# Altium schematic sheet CTRL.SchDoc
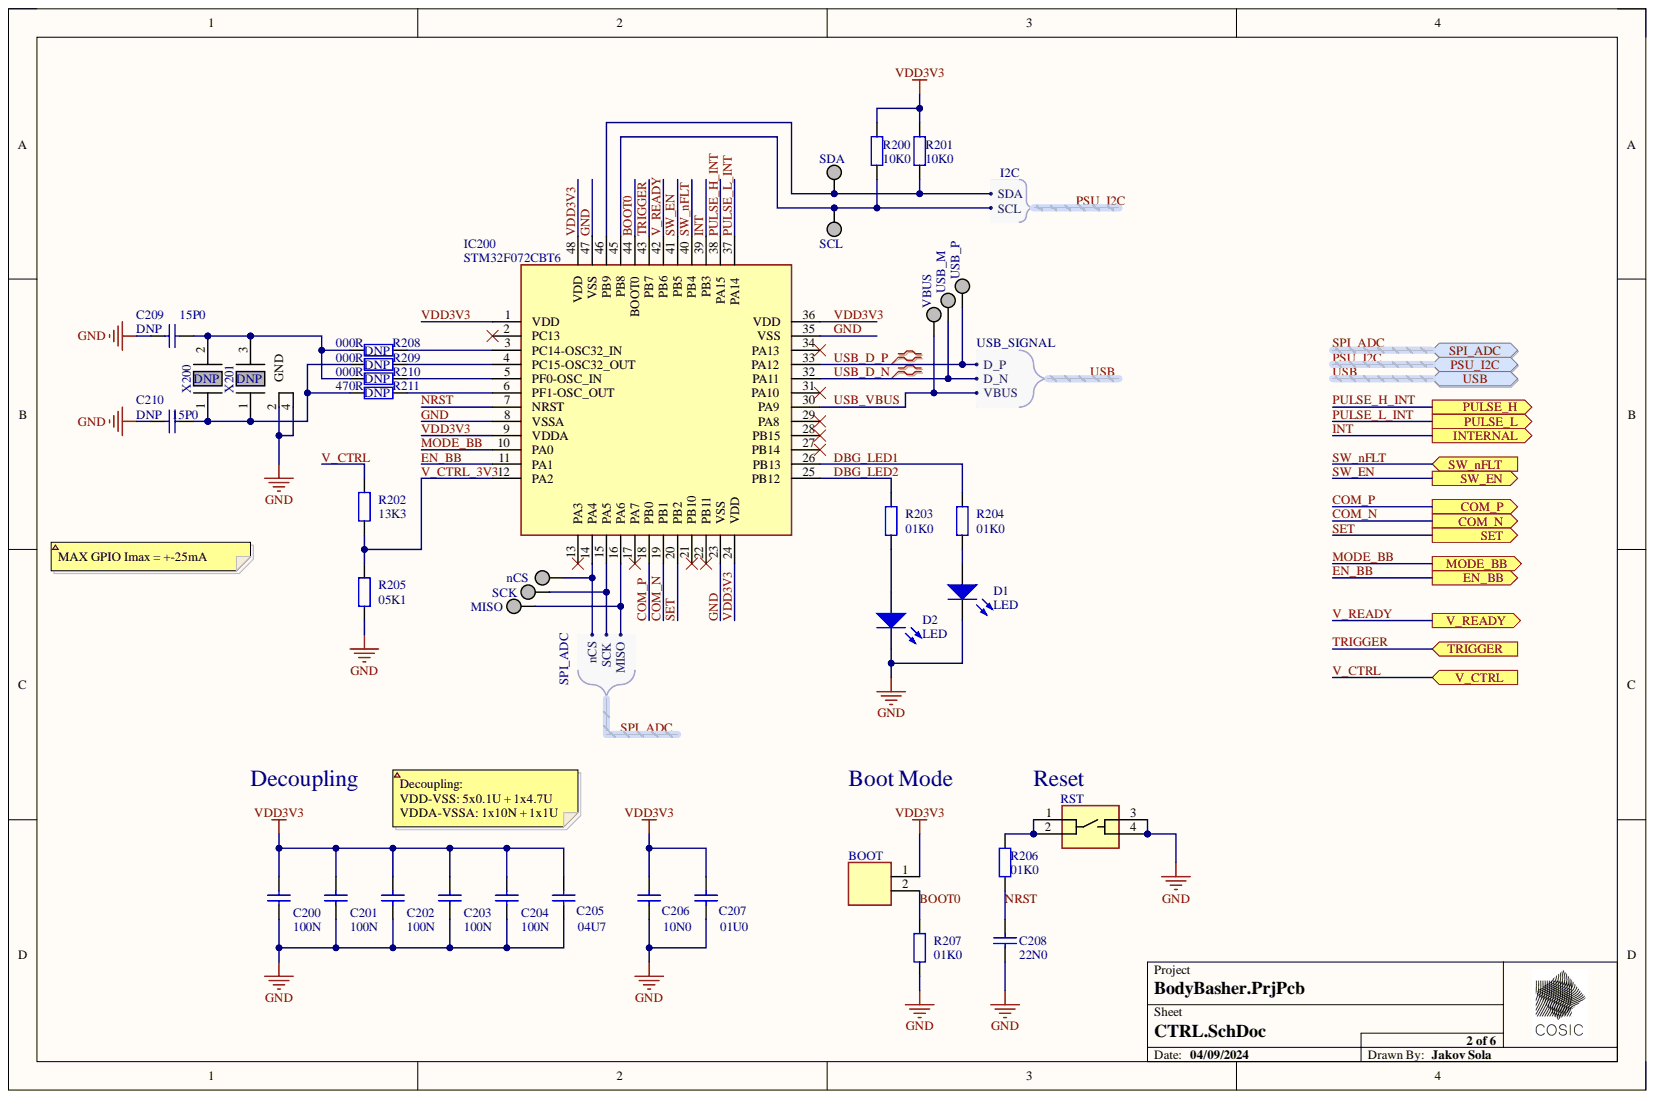
[diagram p0: CTRL.SchDoc]

## Parts
BOOT  CON_2P  [2MMHBS02VT02HTB]  (value not drawn)
C200  value 100N  [CAPC0603N]  (hidden comment: Cap)
C201  value 100N  [CAPC0603N]  (hidden comment: Cap)
C202  value 100N  [CAPC0603N]  (hidden comment: Cap)
C203  value 100N  [CAPC0603N]  (hidden comment: Cap)
C204  value 100N  [CAPC0603N]  (hidden comment: Cap)
C205  value 04U7  [CAPC1206N]  (hidden comment: Cap)
C206  VALUE_4C: 10N0  [CAPC0603N]  (hidden comment: Cap)
C207  VALUE_4C: 01U0  [CAPC0603N]  (hidden comment: Cap)
C208  VALUE_4C: 22N0  [CAPC0603N]  (hidden comment: Cap)
C209  POPULATE: DNP  VALUE_4C: 15P0  [CAPC0603N]  (hidden comment: Cap)
C210  POPULATE: DNP  VALUE_4C: 15P0  [CAPC0603N]  (hidden comment: Cap)
D1  LED  [LED0603_GREEN]
D2  LED  [LED0603_GREEN]
IC200  STM32F0  [LQFP48]
MISO  TP  [TP]  (value not drawn)
nCS  TP  [TP]  (value not drawn)
R200  VALUE_4C: 10K0  [RESC0603N]  (hidden comment: Res)
R201  VALUE_4C: 10K0  [RESC0603N]  (hidden comment: Res)
R202  value 13K3  [RESC0603N]  (hidden comment: Res)
R203  VALUE_4C: 01K0  [RESC0603N]  (hidden comment: Res)
R204  VALUE_4C: 01K0  [RESC0603N]  (hidden comment: Res)
R205  VALUE_4C: 05K1  [RESC0603N]  (hidden comment: Res)
R206  VALUE_4C: 01K0  [RESC0603N]  (hidden comment: Res)
R207  VALUE_4C: 01K0  [RESC0603N]  (hidden comment: Res)
R208  value 000R  POPULATE: DNP  [RESC0603N]  (hidden comment: Res)
R209  value 000R  POPULATE: DNP  [RESC0603N]  (hidden comment: Res)
R210  value 000R  POPULATE: DNP  [RESC0603N]  (hidden comment: Res)
R211  value 470R  POPULATE: DNP  [RESC0603N]  (hidden comment: Res)
RST  4P_BTN  [FSM2J_1]  (value not drawn)
SCK  TP  [TP]  (value not drawn)
SCL  TP  [TP]  (value not drawn)
SDA  TP  [TP]  (value not drawn)
USB_M  TP  [TP]  (value not drawn)
USB_P  TP  [TP]  (value not drawn)
VBUS  TP  [TP]  (value not drawn)
X200  POPULATE: DNP  [XTAL_ABS07-32.768KHZ-7-T]  (hidden comment: CRY_2P)
X201  POPULATE: DNP  [FC3BAEBDI80T1]  (hidden comment: CRY_4P)

## Ports
COM_N, COM_P, EN_BB, INTERNAL, MODE_BB, PSU_I2C, PSU_I2C.SCL, PSU_I2C.SDA, PULSE_H, PULSE_L, SET, SPI_ADC, SPI_ADC.MISO, SPI_ADC.nCS, SPI_ADC.SCK, SW_EN, SW_nFLT, TRIGGER, USB, USB.D_N, USB.D_P, USB.VBUS, V_CTRL, V_READY

## Nets
BOOT0: BOOT.2 IC200.44(BOOT0) R207.2
COM_N: IC200.19(PB1) ; port COM_N
COM_P: IC200.18(PB0) ; port COM_P
DBG_LED1: IC200.26(PB13) R204.2
DBG_LED2: IC200.25(PB12) R203.2
EN_BB: IC200.11(PA1) ; port EN_BB
GND: C200.1 C201.1 C202.1 C203.1 C204.1 C205.1 C206.1 C207.1 C208.1 C209.1 C210.1 D1.2 D2.2 IC200.8(VSSA) IC200.23(VSS) IC200.35(VSS) IC200.47(VSS) R205.1 R207.1 RST.3 RST.4 X201.2(GND) X201.4
INT: IC200.39(PB3) ; port INTERNAL
MODE_BB: IC200.10(PA0) ; port MODE_BB
NRST: C208.2 IC200.7(NRST) R206.1
PSU_I2C.SCL: IC200.45(PB8) R200.1 SCL.TP ; port PSU_I2C
PSU_I2C.SDA: IC200.46(PB9) R201.1 SDA.TP ; port PSU_I2C
PULSE_H_INT: IC200.38(PA15) ; port PULSE_H
PULSE_L_INT: IC200.37(PA14) ; port PULSE_L
SET: IC200.20(PB2) ; port SET
SPI_ADC.MISO: IC200.16(PA6) MISO.TP ; port SPI_ADC
SPI_ADC.nCS: IC200.14(PA4) nCS.TP ; port SPI_ADC
SPI_ADC.SCK: IC200.15(PA5) SCK.TP ; port SPI_ADC
SW_EN: IC200.41(PB5) ; port SW_EN
SW_nFLT: IC200.40(PB4) ; port SW_nFLT
TRIGGER: IC200.43(PB7) ; port TRIGGER
USB.D_N: IC200.32(PA11) USB_M.TP ; port USB
USB.D_P: IC200.33(PA12) USB_P.TP ; port USB
USB.VBUS: IC200.30(PA9) VBUS.TP ; port USB
V_CTRL: R202.2 ; port V_CTRL
V_CTRL_3V3: IC200.12(PA2) R202.1 R205.2
V_READY: IC200.42(PB6) ; port V_READY
VDD3V3: BOOT.1 C200.2 C201.2 C202.2 C203.2 C204.2 C205.2 C206.2 C207.2 IC200.1(VDD) IC200.9(VDDA) IC200.24(VDD) IC200.36(VDD) IC200.48(VDD) R200.2 R201.2
NetC209_2: C209.2 R208.1 R210.1 X200.2 X201.3
NetC210_2: C210.2 R209.1 R211.1 X200.1 X201.1
NetD1_1: D1.1 R204.1
NetD2_1: D2.1 R203.1
NetIC200_2: IC200.2(PC13)
NetIC200_3: IC200.3(PC14-OSC32_IN) R208.2
NetIC200_4: IC200.4(PC15-OSC32_OUT) R209.2
NetIC200_5: IC200.5(PF0-OSC_IN) R210.2
NetIC200_6: IC200.6(PF1-OSC_OUT) R211.2
NetIC200_13: IC200.13(PA3)
NetIC200_17: IC200.17(PA7)
NetIC200_21: IC200.21(PB10)
NetIC200_22: IC200.22(PB11)
NetIC200_27: IC200.27(PB14)
NetIC200_28: IC200.28(PB15)
NetIC200_29: IC200.29(PA8)
NetIC200_31: IC200.31(PA10)
NetIC200_34: IC200.34(PA13)
NetR206_2: R206.2 RST.1 RST.2

## Library data of the parts
BOOT = 2MM-HB-S02-VT-02-H-TB: MANUF: TE CONNECTIVITY; Database Table Name: Misc$; DESCR: CON 2P 2MM HEADER; POPULATE: YES; VENDOR: MOUSER; VENDORCODE: 571-2MM-HBS02VT02HTB; Component Kind: Standard; Library Name: Other_passive.Dblib; MANUFCODE: 2MM-HB-S02-VT-02-H-TB
C200-C204 = CL10B104KA8WPNC: MANUF: SAMSUNG; Database Table Name: Fixed_capacitors$; DESCR: CAP 100N 0603 25V X7R 10%; POPULATE: YES; TOLERANCE: 0.1; VENDOR: DIGI-KEY; VENDORCODE: 1276-6717-1-ND; VOLTAGE: 25V; Component Kind: Standard; Library Name: Capacitors.DbLib; MANUFCODE: CL10B104KA8WPNC; PACKAGE: 0603; TECHNOLOGY: X7R; VALUE_4C: 100N
C205 = CL31B475KBHNNNE: MANUF: SAMSUNG; Database Table Name: Fixed_capacitors$; DESCR: CAP 04U7 1206 50V X7R 10%; POPULATE: YES; TOLERANCE: 0.1; VALUE_PF: 4700000; VENDOR: FARNELL INONE; VENDORCODE: 3013533; VOLTAGE: 50V; Component Kind: Standard; Library Name: Capacitors.DbLib; MANUFCODE: CL31B475KBHNNNE; PACKAGE: 1206; TECHNOLOGY: X7R; VALUE_4C: 04U7
C206 = C0603C103K8RACTU: MANUF: KEMET; Database Table Name: Fixed_capacitors$; DESCR: CAP 10N0 0603 10V X7R 10%; POPULATE: YES; TOLERANCE: 0.1; VALUE: 10N; VENDOR: DIGI-KEY; VENDORCODE: 399-11132-1-ND; VOLTAGE: 10V; Component Kind: Standard; Library Name: Capacitors.DbLib; MANUFCODE: C0603C103K8RACTU; PACKAGE: 0603; TECHNOLOGY: X7R
C207 = C0603C105K4PAC-TU: MANUF: KEMET; Database Table Name: Fixed_capacitors$; DESCR: CAP 01U0 0603 16V X5R 10%; POPULATE: YES; TOLERANCE: 0.1; VALUE_PF: 1000000; VENDOR: FARNELL INONE; VENDORCODE: 1463388; VOLTAGE: 16V; Component Kind: Standard; Library Name: Capacitors.DbLib; MANUFCODE: C0603C105K4PAC-TU; PACKAGE: 0603; TECHNOLOGY: X5R
C208 = C0603C223K8RAC7867: MANUF: KEMET; Database Table Name: Fixed_capacitors$; DESCR: CAP 22N0 0603 10V X7R 10%; POPULATE: YES; TOLERANCE: 0.1; VALUE: 22N; VENDOR: DIGI-KEY; VENDORCODE: 399-14505-1-ND; VOLTAGE: 10V; Component Kind: Standard; Library Name: Capacitors.DbLib; MANUFCODE: C0603C223K8RAC7867; PACKAGE: 0603; TECHNOLOGY: X7R
C209 C210 = C0603C150K8RAC: MANUF: KEMET; Database Table Name: Fixed_capacitors$; DESCR: CAP 15P0 0603 10V X7R 10%; TOLERANCE: 0.1; VALUE: 15P; VALUE_PF: 15; VOLTAGE: 10V; Component Kind: Standard; Library Name: Capacitors.DbLib; MANUFCODE: C0603C150K8RAC; PACKAGE: 0603; TECHNOLOGY: X7R
D1 D2 = ASMT-RF45: MANUF: AVAGO TECHNOLOGIES; COLOR: GREEN; Database Table Name: LEDs$; DESCR: LED GREEN 0603 20MA 2.4V 60MCD; IF: 20MA; INTENSITY: 60MCD; POPULATE: YES; VENDOR: FARNELL INONE; VENDORCODE: 1652078; VF: 2.4V; Component Kind: Standard; Library Name: Diodes.Dblib; MANUFCODE: ASMT-RF45; PACKAGE: 0603; QTY: SINGLE; REMARK: GREEN
IC200 = STM32F072CBT6: MANUF: STM; Database Table Name: IC$; DESCR: IC MCU ARM CORTEX-M0 128KB 48MHZ USB; POPULATE: YES; VENDOR: FARNELL; VENDORCODE: 2432094; Component Kind: Standard; Library Name: Integrated_circuits.DbLib; MANUFCODE: STM32F072CBT6
MISO nCS SCK SCL SDA USB_M USB_P VBUS = TP: Database Table Name: Misc$; DESCR: TESTPOINT 1.5MM; POPULATE: NA; Component Kind: Standard; Library Name: Other_passive.Dblib; MANUFCODE: TP
R200 R201 = CRCW060310K0FKEA: Resistor; MANUF: VISHAY; Database Table Name: Fixed_resistors$; DESCR: RES 10K0 0603 0.1W 1% 75V 100PPM; NPOWER: 0.1; NTEMPCOEFF: 100; NTOLERANCE: 0.01; NVALUE: 10000000; NVOLTAGE: 75; POPULATE: YES; POWER: 0.1W; TEMPCOEFF: 100PPM; TOLERANCE: 1%; VALUE: 10K; VENDOR: DIGI-KEY; VENDORCODE: 541-10.0KHCT-ND; VOLTAGE: 75V; Component Kind: Standard; Library Name: Resistors.Dblib; MANUFCODE: CRCW060310K0FKEA; PACKAGE: 0603; VALUE_MOHM: 10000000
R202 = CRCW060313K3FKEA: Resistor; MANUF: VISHAY; Database Table Name: Fixed_resistors$; DESCR: RES 13K3 0603 0.1W 1% 75V 100PPM; NPOWER: 0.1; NTEMPCOEFF: 100; NTOLERANCE: 0.01; NVALUE: 13300000; NVOLTAGE: 75; POPULATE: YES; POWER: 0.1W; TEMPCOEFF: 100PPM; TOLERANCE: 1%; VENDOR: FARNELL INONE; VENDORCODE: 1652840; VOLTAGE: 75V; Component Kind: Standard; Library Name: Resistors.Dblib; MANUFCODE: CRCW060313K3FKEA; PACKAGE: 0603; VALUE_4C: 13K3; VALUE_MOHM: 13300000
R203 R204 R206 R207 = MC 0.063W 0603 1% 1K: Resistor; MANUF: MULTICOMP; Database Table Name: Fixed_resistors$; DESCR: RES 01K0 0603 0.063W 1% 50V 100PPM; NPOWER: 0.063; NTEMPCOEFF: 100; NTOLERANCE: 0.01; NVALUE: 1000000; NVOLTAGE: 50; POPULATE: YES; POWER: 0.063W; TEMPCOEFF: 100PPM; TOLERANCE: 1%; VALUE: 1K; VENDOR: FARNELL INONE; VENDORCODE: 9330380; VOLTAGE: 50V; Component Kind: Standard; Library Name: Resistors.Dblib; MANUFCODE: MC 0.063W 0603 1% 1K; PACKAGE: 0603; PCB3D: RES0603; VALUE_MOHM: 1000000
R205 = RC0603FR-075K1L: Resistor; MANUF: YAGEO; Database Table Name: Fixed_resistors$; DESCR: RES 05K1 0603 0.1W 1% 75V 100PPM; NTEMPCOEFF: 100; NVALUE: 5100000; NVOLTAGE: 75; POPULATE: YES; POWER: 0.1W; TEMPCOEFF: 100PPM; TOLERANCE: 1%; VALUE: 5K1; VENDOR: DIGI-KEY; VENDORCODE: 311-5.10KHRCT-ND; VOLTAGE: 75V; Component Kind: Standard; Library Name: Resistors.Dblib; MANUFCODE: RC0603FR-075K1L; PACKAGE: 603; VALUE_MOHM: 5100000
R208-R210 = CRCW06030000Z0EA: Resistor; MANUF: VISHAY; Database Table Name: Fixed_resistors$; DESCR: RES 000R 0603 0.1W 1% 75V 100PPM; NPOWER: 0.1; NTEMPCOEFF: 100; NTOLERANCE: 0.01; NVALUE: 0; NVOLTAGE: 75; POWER: 0.1W; TEMPCOEFF: 100PPM; TOLERANCE: 1%; VENDOR: DIGI-KEY; VENDORCODE: 541-0.0GTR-ND; VOLTAGE: 75V; Component Kind: Standard; Library Name: Resistors.Dblib; MANUFCODE: CRCW06030000Z0EA; PACKAGE: 0603; VALUE_4C: 000R; VALUE_MOHM: 0
R211 = ERA3AEB471V: Resistor; MANUF: PANASONIC; Database Table Name: Fixed_resistors$; DESCR: RES 470R 0603 0.1W 0.1% 75V 25PPM; NPOWER: 0.1; NTEMPCOEFF: 25; NTOLERANCE: 0.001; NVALUE: 470000; NVOLTAGE: 75; POWER: 0.1W; TEMPCOEFF: 25PPM; TOLERANCE: 0.1%; VENDOR: FARNELL INONE; VENDORCODE: 1577601; VOLTAGE: 75V; Component Kind: Standard; Library Name: Resistors.Dblib; MANUFCODE: ERA3AEB471V; PACKAGE: 0603; PCB3D: RES0603; VALUE_4C: 470R; VALUE_MOHM: 470000
RST = FSM2JSMAATR: MANUF: TE CONNECTIVITY; Database Table Name: Misc$; DESCR: BTN 24V 4P SMD; POPULATE: YES; VENDOR: MOUSER; VENDORCODE: 506-FSM2JSMAATR; Component Kind: Standard; Library Name: Other_passive.Dblib; MANUFCODE: FSM2JSMAATR
X200 = ABS07-32.768KHZ-7-T: MANUF: ABRACON; Database Table Name: Misc$; DESCR: CRYSTAL 32.768KHZ 7PF 20PPM SMD; VENDOR: MOUSER; VENDORCODE: 815-ABS07-32.768K7T; Component Kind: Standard; Library Name: Other_passive.Dblib; MANUFCODE: ABS07-32.768KHZ-7-T
X201 = FC3BAEBDI8.0-T1: MANUF: ABRACON; Database Table Name: Misc$; DESCR: CRYSTAL 8MHZ 8PF 20PPM SMD; VENDOR: MOUSER; VENDORCODE: 815-FC3BAEBDI8.0-T1; Component Kind: Standard; Library Name: Other_passive.Dblib; MANUFCODE: FC3BAEBDI8.0-T1
